# Altium schematic sheet CAN.SchDoc
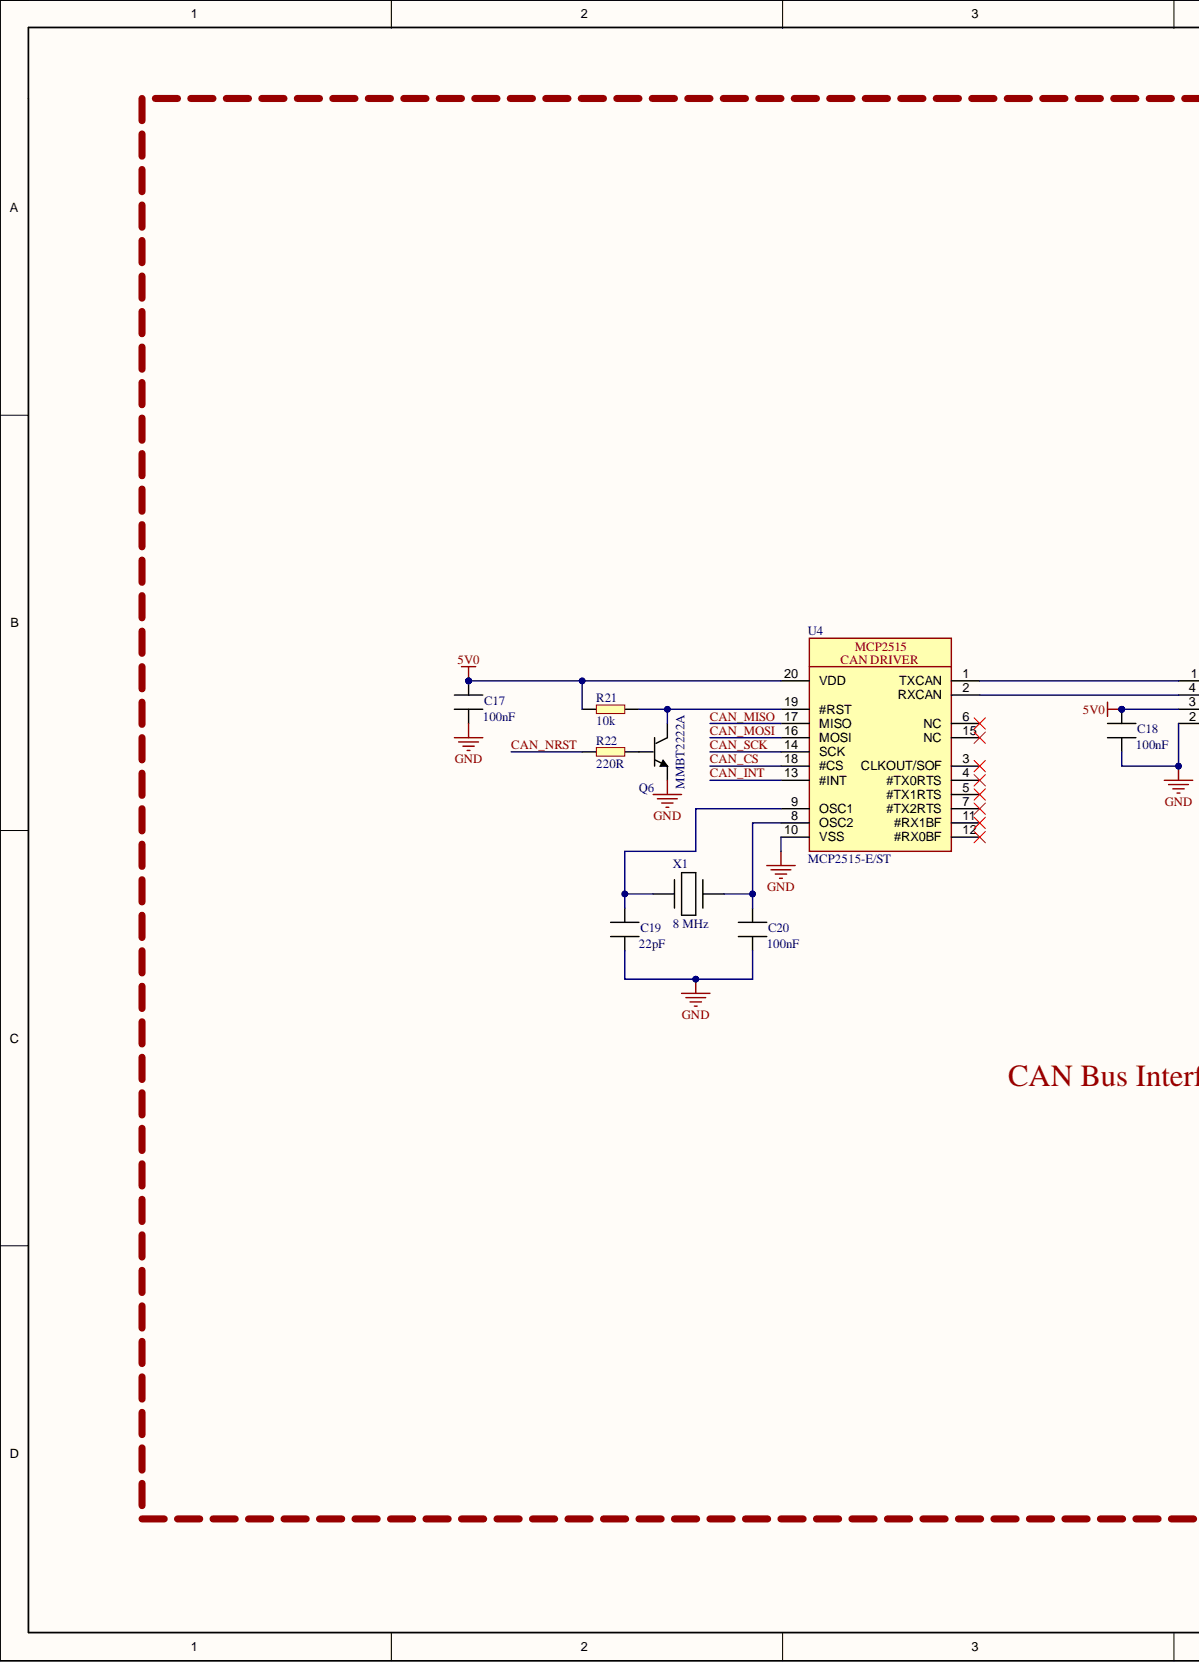
[diagram p0: CAN.SchDoc, left]
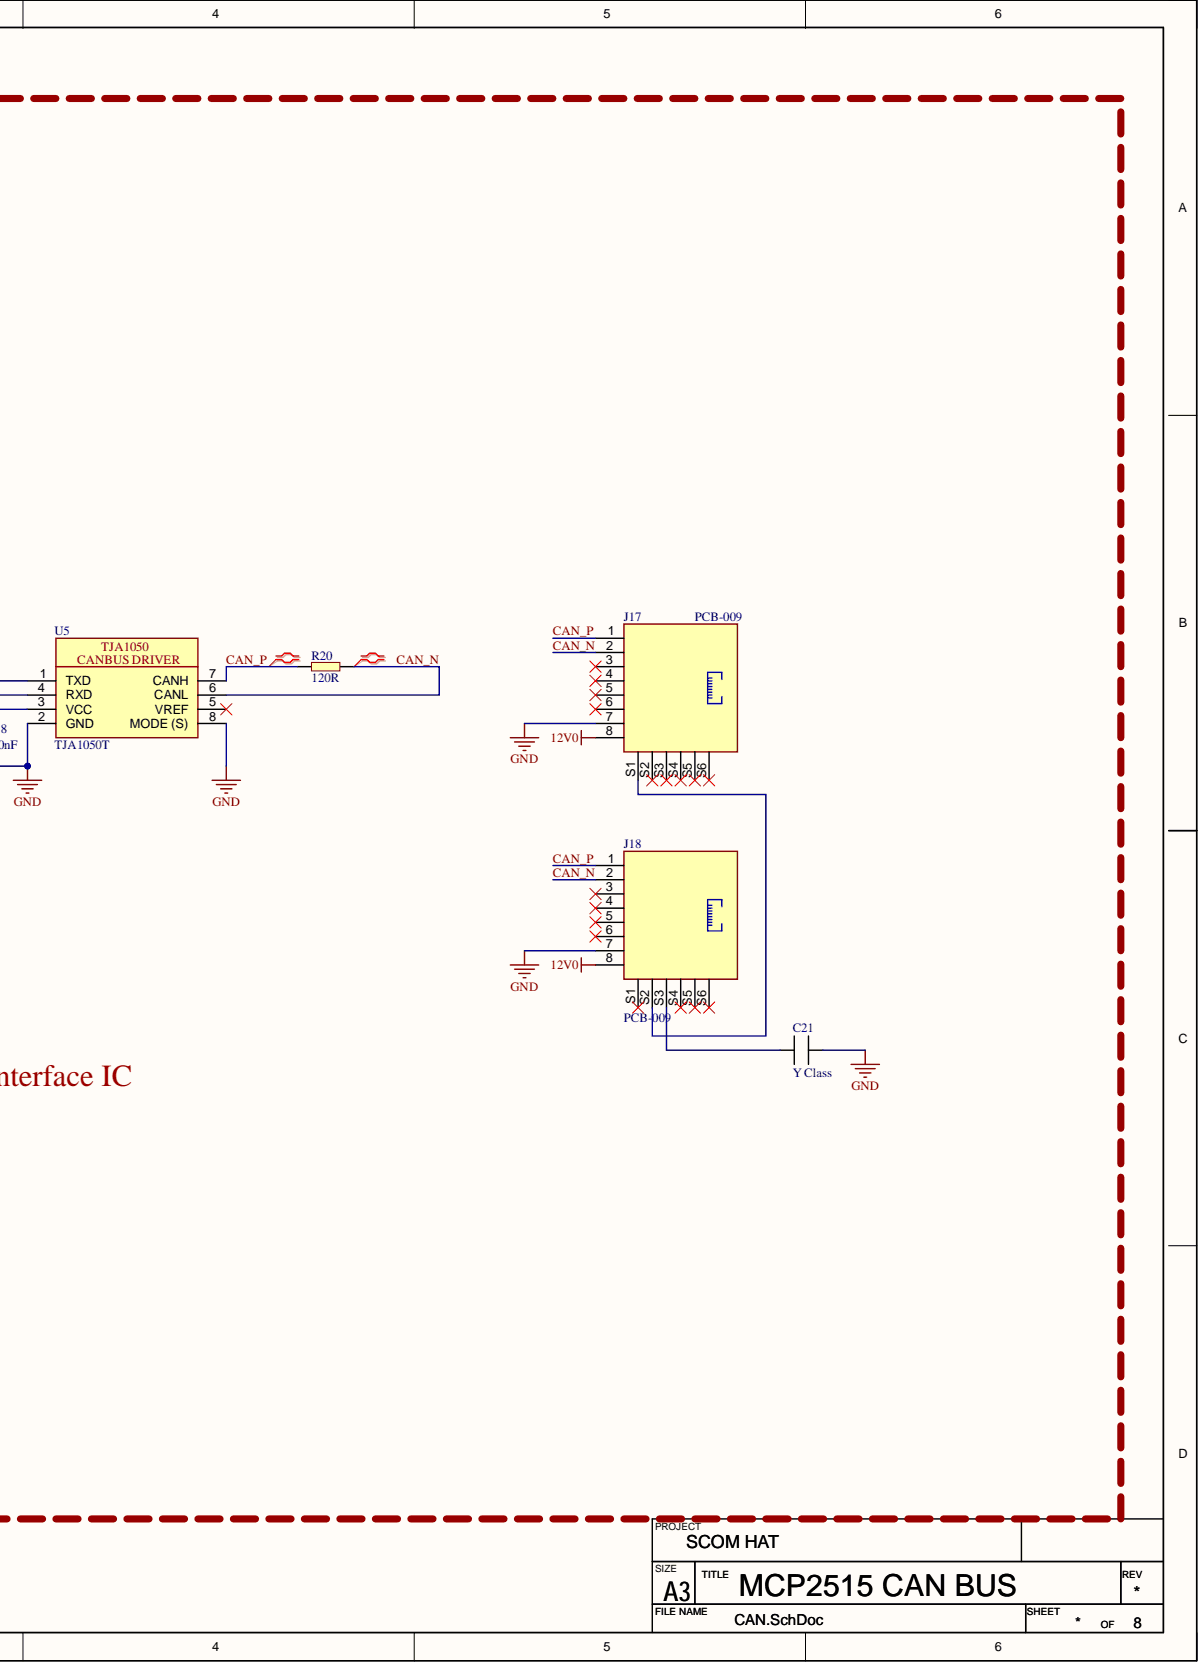
[diagram p1: CAN.SchDoc, right]

## Parts
C17  value 100nF  [CAPC2012X13L]  (hidden comment: MLCC_0805)
C18  value 100nF  [CAPC2012X13L]  (hidden comment: MLCC_0805)
C19  value 22pF  [CAPC2012X13L]  (hidden comment: MLCC_0805)
C20  value 100nF  [CAPC2012X13L]  (hidden comment: MLCC_0805)
C21  value Y Class  [VY1222M43Y5UC63V0]  (hidden comment: VY1)  (no BOM)
J17  value PCB-009  [PCB-009]  (hidden comment: ERP_148-3)
J18  value PCB-009  [PCB-009]  (hidden comment: ERP_148-3)
Q6  value MMBT2222A  [MMBT2222]  (hidden comment: ERP_105-1)
R20  value 120R  [RES_0603]  (hidden comment: ERP_101-1)
R21  value 10k  [RES_0603]  (hidden comment: ERP_101-1)
R22  value 220R  [RES_0603]  (hidden comment: ERP_101-1)
U4  MCP2515  value MCP2515-E/ST  [MCP2515-ST]
U5  TJA1050  value TJA1050T  [TJA1050T]
X1  value 8 MHz  [HC-49US]  (hidden comment: HC-49US)

## Nets
5V0: C17.1 C18.1 R21.1 U4.20(VDD) U5.3(VCC)
12V0: J17.8 J18.8
GND: C17.2 C18.2 C19.2 C20.2 C21.2 J17.7 J18.7 Q6.2 U4.10(VSS) U5.2(GND) U5.8(MODE_(S))
CAN_CS: U4.18(#CS)
CAN_INT: U4.13(#INT)
CAN_MISO: U4.17(MISO)
CAN_MOSI: U4.16(MOSI)
CAN_N: J17.2 J18.2 R20.2 U5.6(CANL)
CAN_NRST: R22.1
CAN_P: J17.1 J18.1 R20.1 U5.7(CANH)
CAN_SCK: U4.14(SCK)
NetC19_1: C19.1 U4.9(OSC1) X1.1
NetC20_1: C20.1 U4.8(OSC2) X1.2
NetC21_1: C21.1 J18.S3
NetJ17_S1: J17.S1 J18.S2
NetQ6_1: Q6.1 R22.2
NetQ6_3: Q6.3 R21.2 U4.19(#RST)
NetU4_1: U4.1(TXCAN) U5.1(TXD)
NetU4_2: U4.2(RXCAN) U5.4(RXD)

Not connected: J17 3 4 5 6 S2 S3 S4 S5 S6; J18 3 4 5 6 S1 S4 S5 S6; U4 3(CLKOUT/SOF) 4(#TX0RTS) 5(#TX1RTS) 6(NC) 7(#TX2RTS) 11(#RX1BF) 12(#RX0BF) 15(NC); U5 5(VREF)

## Library data of the parts
C17-C20 = MLCC_0805: Chip Capacitor, 2-Leads, Body 2.00x1.25mm, IPC High Density; Component Kind: Standard; Library Name: Capacitor.SchLib; Package: 0805
C21 = VY1: Ceramic Capacitor Y5U (E) Radial, Disc; Library Name: Capacitor.SchLib
J17 J18 = RJ45_Top_Entry: PCB-009 Robu; Component Kind: Standard; Library Name: Connectors.SchLib; Package: 8P8C; Supplier 1: Robu; Supplier Part Number 1: 1883509
Q6 = MMBT2222: MMBT2222A; Component Kind: Standard; Library Name: BJT.SchLib; Package: SOT23
R20-R22 = RES_0603: Manufacturer Part Number 1: 560112116003; Component Kind: Standard; Description: RES_0603; Library Name: Resistor.SchLib; Package: 0603
U4 = MCP2515: CAN Interface IC; Component Kind: Standard; Library Name: Communication.SchLib
U5 = TJA1050: CAN Transceiver IC; Component Kind: Standard; Library Name: Communication.SchLib
X1 = HC-49US: CRYSTAL HC-49US 2 Pin; Component Kind: Standard; Library Name: Crystal.SchLib; Package: HC-49US
